# Altium schematic sheet USB.SchDoc
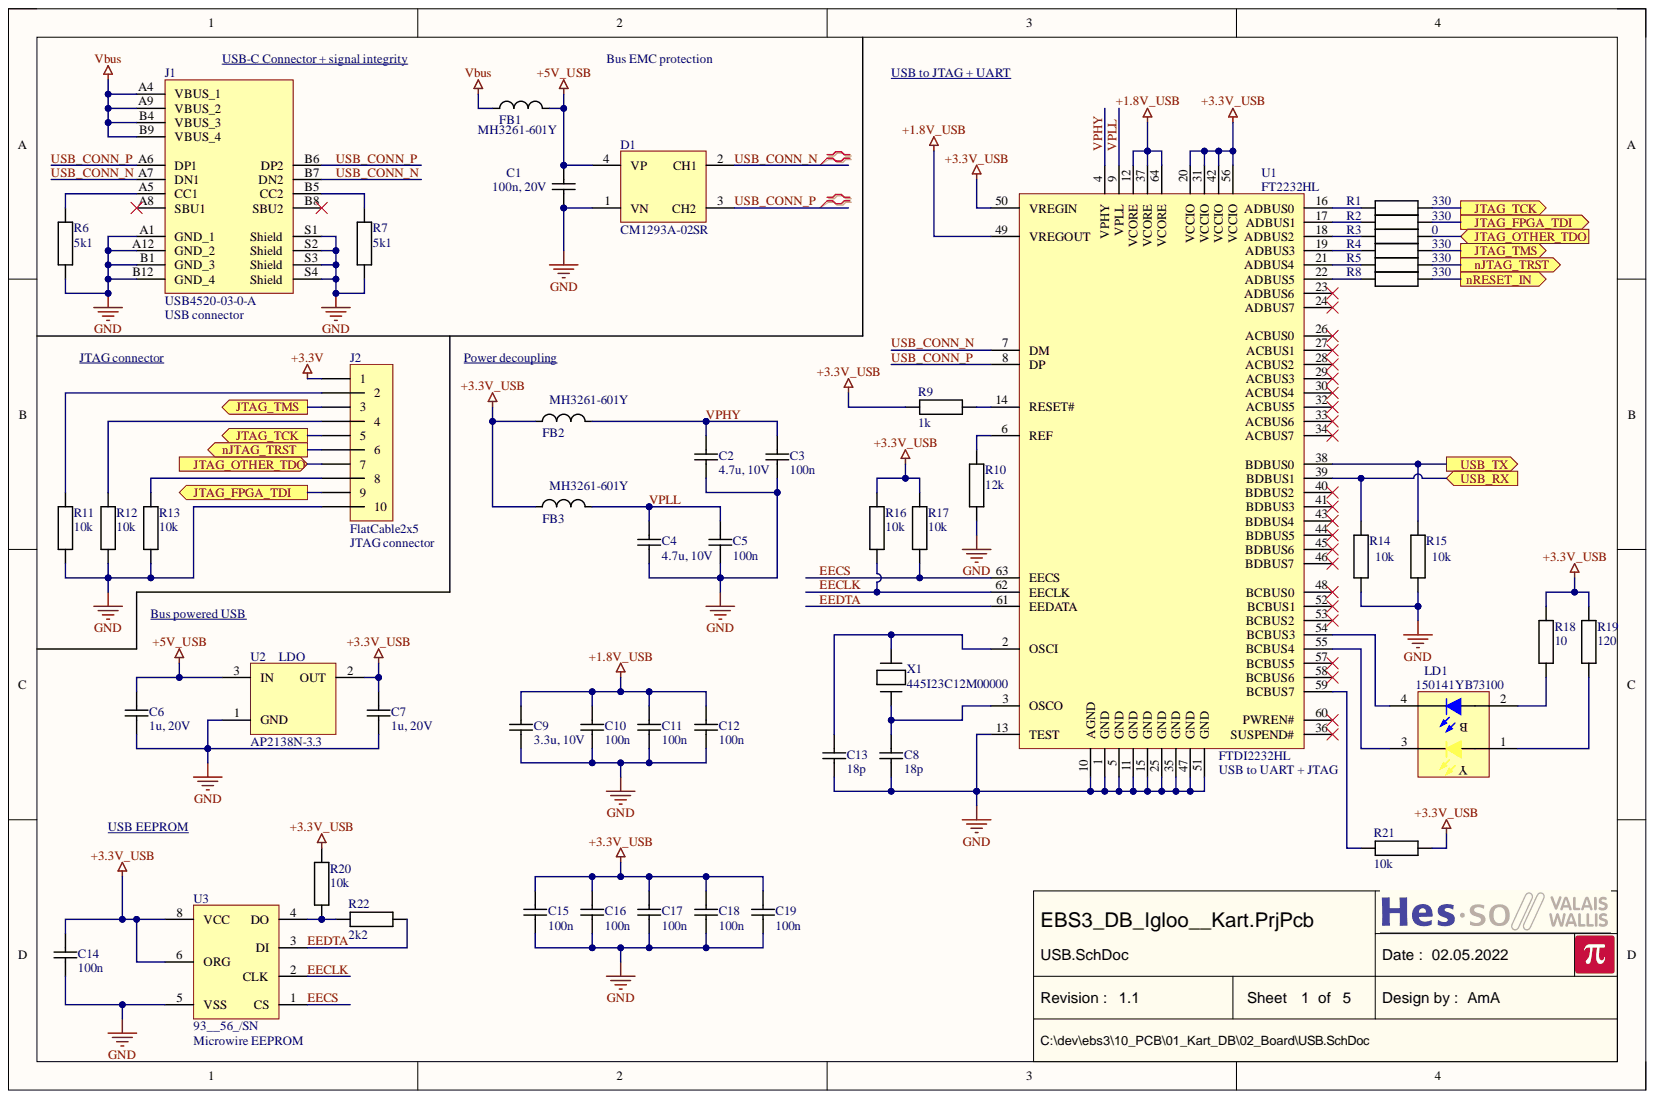
[diagram p0: USB.SchDoc]

## Parts
C1  100n, 20V  [CAPACITOR 1608 REFLOW]
C2  4.7u, 10V  [CAPACITOR 2012 REFLOW]
C3  100n  [CAPACITOR 1608 REFLOW]
C4  4.7u, 10V  [CAPACITOR 2012 REFLOW]
C5  100n  [CAPACITOR 1608 REFLOW]
C6  1u, 20V  [CAPACITOR 1608 REFLOW]
C7  1u, 20V  [CAPACITOR 1608 REFLOW]
C8  18p  [CAPACITOR 1608 REFLOW]
C9  3.3u, 10V  [CAPACITOR 2012 REFLOW]
C10  100n  [CAPACITOR 1608 REFLOW]
C11  100n  [CAPACITOR 1608 REFLOW]
C12  100n  [CAPACITOR 1608 REFLOW]
C13  18p  [CAPACITOR 1608 REFLOW]
C14  100n  [CAPACITOR 1608 REFLOW]
C15  100n  [CAPACITOR 1608 REFLOW]
C16  100n  [CAPACITOR 1608 REFLOW]
C17  100n  [CAPACITOR 1608 REFLOW]
C18  100n  [CAPACITOR 1608 REFLOW]
C19  100n  [CAPACITOR 1608 REFLOW]
D1  CM1293A-02SR  [SOT143 P1.9 C1.4X3.0 H1.1]
FB1  MH3261-601Y  [INDUCTOR 3216 REFLOW]
FB2  MH3261-601Y  [INDUCTOR 3216 REFLOW]
FB3  MH3261-601Y  [INDUCTOR 3216 REFLOW]
J1  USB4520-03-0-A  [USB-C-SMD GCT USB4520030A]
J2  FlatCable2x5  [FlatCable2x5 2MM Straight TH FCI 98414-G06-10ULF]
LD1  150141YB73100  [LED WE 150141YB73100]
R1  330  [RESISTOR 1608 REFLOW]
R2  330  [RESISTOR 1608 REFLOW]
R3  0  [RESISTOR 1608 REFLOW]
R4  330  [RESISTOR 1608 REFLOW]
R5  330  [RESISTOR 1608 REFLOW]
R6  5k1  [RESISTOR 1608 REFLOW]
R7  5k1  [RESISTOR 1608 REFLOW]
R8  330  [RESISTOR 1608 REFLOW]
R9  1k  [RESISTOR 1608 REFLOW]
R10  12k  [RESISTOR 1608 REFLOW]
R11  10k  [RESISTOR 1608 REFLOW]
R12  10k  [RESISTOR 1608 REFLOW]
R13  10k  [RESISTOR 1608 REFLOW]
R14  10k  [RESISTOR 1608 REFLOW]
R15  10k  [RESISTOR 1608 REFLOW]
R16  10k  [RESISTOR 1608 REFLOW]
R17  10k  [RESISTOR 1608 REFLOW]
R18  10  [RESISTOR 1608 REFLOW]
R19  120  [RESISTOR 1608 REFLOW]
R20  10k  [RESISTOR 1608 REFLOW]
R21  10k  [RESISTOR 1608 REFLOW]
R22  2k2  [RESISTOR 1608 REFLOW]
U1  FT2232HL  [LQFP64 P0.5 C10.0x10.0 H1.6]
U2  AP2138N-3.3  [SOT23 P0.95 C1.6x3.0 H1.3]
U3  93__56_/SN  [SO8 P1.27 C4.0X5.0 H1.75]
X1  445I23C12M00000  [CRYSTAL C5.0x3.2 CTS 445]

## Ports
JTAG_FPGA_TDI, JTAG_OTHER_TDO, JTAG_TCK, JTAG_TMS, nJTAG_TRST, nRESET_IN, USB_RX, USB_TX

## Nets
+1.8V_USB: C9.2 C10.2 C11.2 C12.2 U1.12(VCORE) U1.37(VCORE) U1.49(VREGOUT) U1.64(VCORE)
+3.3V: J2.1
+3.3V_USB: C7.2 C14.1 C15.2 C16.2 C17.2 C18.2 C19.2 FB2.1 FB3.1 R9.2 R16.2 R17.2 R18.2 R19.2 R20.1 R21.2 U1.20(VCCIO) U1.31(VCCIO) U1.42(VCCIO) U1.50(VREGIN) U1.56(VCCIO) U2.2 U3.6(ORG) U3.8(VCC)
+5V_USB: C1.2 C6.2 D1.4(VP) FB1.2 U2.3
GND: C1.1 C2.1 C3.1 C4.1 C5.1 C6.1 C7.1 C8.2 C9.1 C10.1 C11.1 C12.1 C13.1 C14.2 C15.1 C16.1 C17.1 C18.1 C19.1 D1.1(VN) J1.A1(GND_1) J1.A12(GND_2) J1.B1(GND_3) J1.B12(GND_4) J1.S1(Shield) J1.S2(Shield) J1.S3(Shield) J1.S4(Shield) J2.10 R6.1 R7.1 R10.2 R11.2 R12.2 R13.2 R14.2 R15.2 U1.1(GND) U1.5(GND) U1.10(AGND) U1.11(GND) U1.13(TEST) U1.15(GND) U1.25(GND) U1.35(GND) U1.47(GND) U1.51(GND) U2.1 U3.5(VSS)
Vbus: FB1.1 J1.A4(VBUS_1) J1.A9(VBUS_2) J1.B4(VBUS_3) J1.B9(VBUS_4)
EECLK: R16.1 U1.62(EECLK) U3.2(CLK)
EECS: R17.1 U1.63(EECS) U3.1(CS)
EEDTA: R22.1 U1.61(EEDATA) U3.3(DI)
JTAG_FPGA_TDI: J2.9 R2.1 ; port JTAG_FPGA_TDI
JTAG_OTHER_TDO: J2.7 R3.1 ; port JTAG_OTHER_TDO
JTAG_TCK: J2.5 R1.1 ; port JTAG_TCK
JTAG_TMS: J2.3 R4.1 ; port JTAG_TMS
nJTAG_TRST: J2.6 R5.1 ; port nJTAG_TRST
nRESET_IN: R8.1 ; port nRESET_IN
USB_CONN_N: D1.2(CH1) J1.A7(DN1) J1.B7(DN2) U1.7(DM)
USB_CONN_P: D1.3(CH2) J1.A6(DP1) J1.B6(DP2) U1.8(DP)
USB_RX: R14.1 U1.39(BDBUS1) ; port USB_RX
USB_TX: R15.1 U1.38(BDBUS0) ; port USB_TX
VPHY: C2.2 C3.2 FB2.2 U1.4(VPHY)
VPLL: C4.2 C5.2 FB3.2 U1.9(VPLL)
NetC8_1: C8.1 U1.3(OSCO) X1.1
NetC13_2: C13.2 U1.2(OSCI) X1.2
NetJ1_A5: J1.A5(CC1) R6.2
NetJ1_B5: J1.B5(CC2) R7.2
NetJ2_2: J2.2 R11.1
NetJ2_4: J2.4 R12.1
NetJ2_8: J2.8 R13.1
NetLD1_1: LD1.1 R19.1
NetLD1_2: LD1.2 R18.1
NetLD1_3: LD1.3 U1.55(BCBUS4)
NetLD1_4: LD1.4 U1.54(BCBUS3)
NetR1_2: R1.2 U1.16(ADBUS0)
NetR2_2: R2.2 U1.17(ADBUS1)
NetR3_2: R3.2 U1.18(ADBUS2)
NetR4_2: R4.2 U1.19(ADBUS3)
NetR5_2: R5.2 U1.21(ADBUS4)
NetR8_2: R8.2 U1.22(ADBUS5)
NetR9_1: R9.1 U1.14(RESET#)
NetR10_1: R10.1 U1.6(REF)
NetR20_2: R20.2 R22.2 U3.4(DO)
NetR21_1: R21.1 U1.59(BCBUS7)

Not connected: J1 A8(SBU1) B8(SBU2); U1 23(ADBUS6) 24(ADBUS7) 26(ACBUS0) 27(ACBUS1) 28(ACBUS2) 29(ACBUS3) 30(ACBUS4) 32(ACBUS5) 33(ACBUS6) 34(ACBUS7) 36(SUSPEND#) 40(BDBUS2) 41(BDBUS3) 43(BDBUS4) 44(BDBUS5) 45(BDBUS6) 46(BDBUS7) 48(BCBUS0) 52(BCBUS1) 53(BCBUS2) 57(BCBUS5) 58(BCBUS6) 60(PWREN#)

## Library data of the parts
C1-C19 = Capacitor: Component Kind: Standard; Description: Capacitor; Library Name: Capacitor.IntLib
  C1: Value: 100n, 20V
  C2 C4: Value: 4.7u, 10V
  C3 C5 C10-C12 C14-C19: Value: 100n
  C6 C7: Value: 1u, 20V
  C8 C13: Value: 18p
  C9: Value: 3.3u, 10V
D1 = CM1293A-02SR: ESD Protection Array 2 Channels; Component Kind: Standard; Library Name: Diode.IntLib; Supplier 1: Arrow Electronics; Supplier Part Number 1: CM1293A-02SR; Value: CM1293A-02SR
FB1-FB3 = Inductor: Component Kind: Standard; Description: Inductor; Library Name: Inductor.IntLib; Value: MH3261-601Y
J1 = USB-C SMD GTC USB4520-03-0-A: Connector; Component Kind: Standard; Library Name: Connector.IntLib; Value: USB4520-03-0-A
LD1 = LED WE 150141YB73100: 3.5x2.8mm SMD Bi-color TOP LED; Component Kind: Standard; Library Name: Diode.IntLib; Value: 150141YB73100
R1-R22 = Resistor: Component Kind: Standard; Description: Resistor; Library Name: Resistor.IntLib
  R1 R2 R4 R5 R8: Value: 330
  R3: Value: 0
  R6 R7: Value: 5k1
  R9: Value: 1k
  R10: Value: 12k
  R11-R17 R20 R21: Value: 10k
  R18: Value: 10
  R19: Value: 120
  R22: Value: 2k2
U1 = FT2232HL: Dual High Speed  USB to Multipurpose  UART/FIFO IC; Component Kind: Standard; Library Name: IntegratedCircuit.IntLib; Value: FT2232HL
U2 = REGPOS SOT23 GND,OUT,IN: Generic Positive Voltage Regulator; Component Kind: Standard; Library Name: Regulator.IntLib; Value: AP2138N-3.3
U3 = 93__56_/SN: 2K bit low voltage serial EEPROMs, Without ORG Pin; Component Kind: Standard; Value: 93__56_/SN; Library Name: IglooDB.SchLib
X1 = Crystal  C5.0x3.2 CTS 445: Component Kind: Standard; Description: Crystal  C5.0x3.2 CTS 445; Library Name: Oscillator.IntLib; Value: 445I23C12M00000
J2 = FlatCable2x5: Component Kind: Standard; Description: FlatCable2x5; Library Name: Connector.IntLib
